# Altium schematic sheet FPGA_MISC.SchDoc(FPGA_MISC)
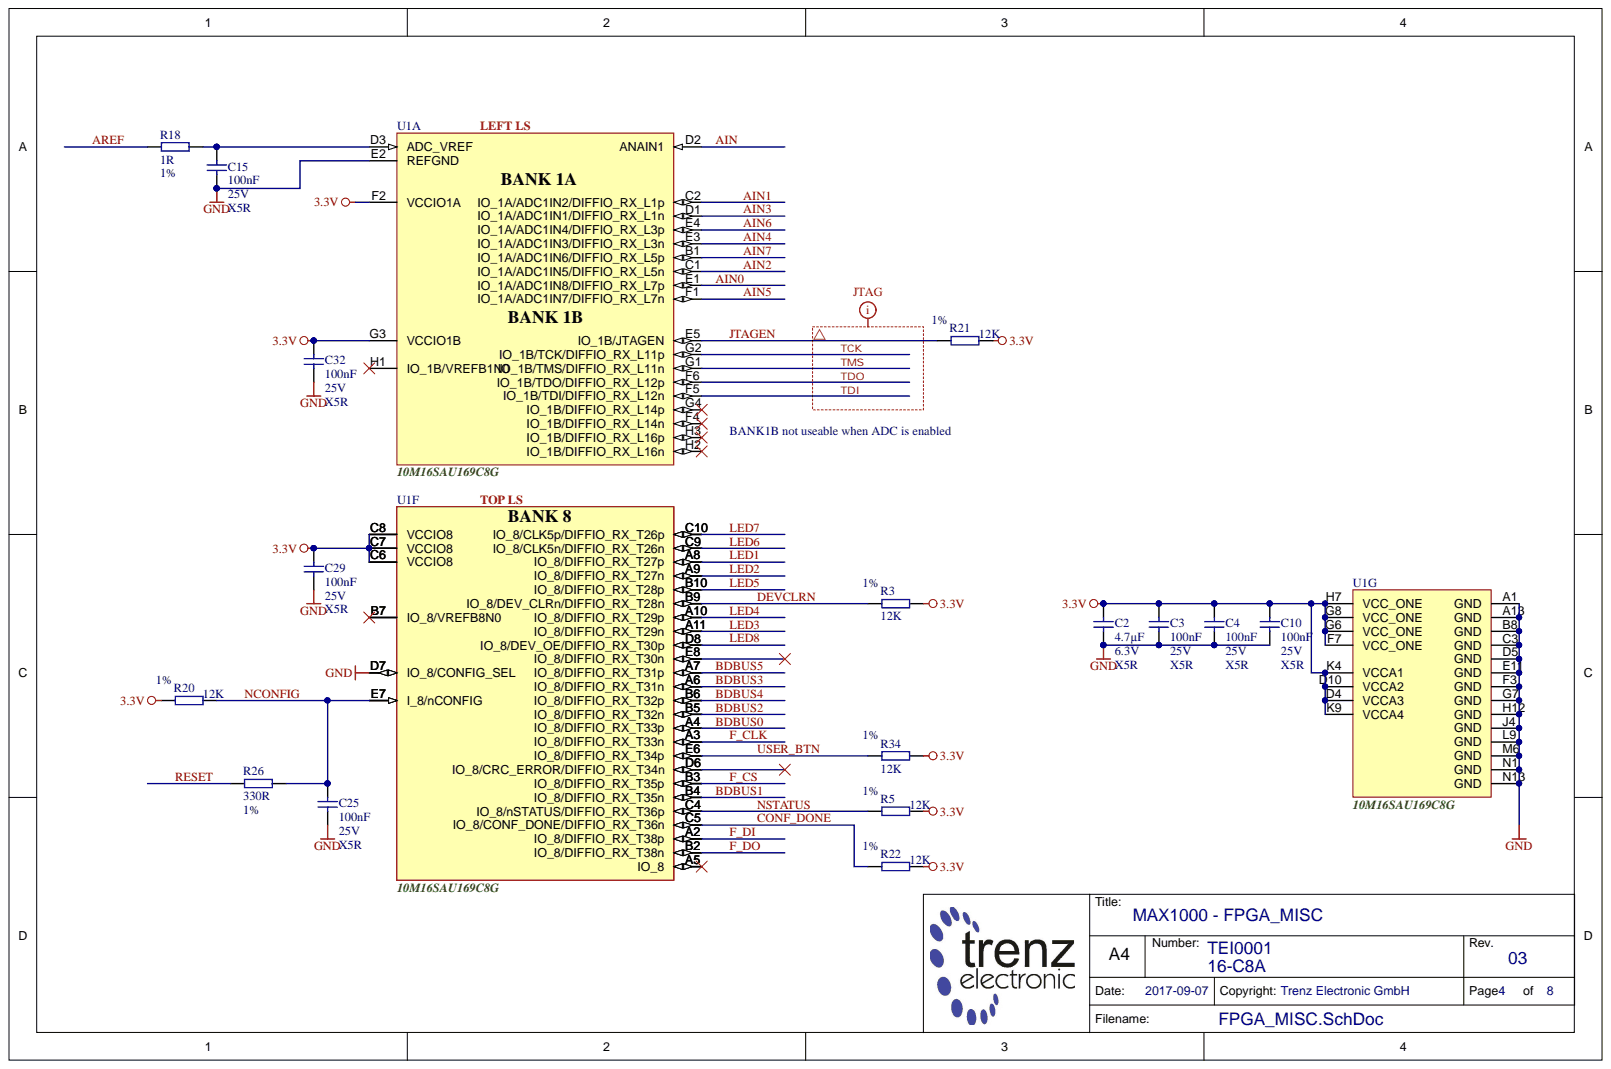
[diagram p0: FPGA_MISC.SchDoc]

## Parts
C2  4.7µF  Technology: X5R  Voltage: 6.3V  [CAPC1005X60N]
C3  100nF  Technology: X5R  Voltage: 25V  [CAPC1005X55N]
C4  100nF  Technology: X5R  Voltage: 25V  [CAPC1005X55N]
C10  100nF  Technology: X5R  Voltage: 25V  [CAPC1005X55N]
C15  100nF  Technology: X5R  Voltage: 25V  [CAPC1005X55N]
C25  100nF  Voltage: 25V  [CAPC1005X55N]
C29  100nF  Technology: X5R  Voltage: 25V  [CAPC1005X55N]
C32  100nF  Technology: X5R  Voltage: 25V  [CAPC1005X55N]
R3  12K  Tolerance: 1%  [RESC1005X40N]
R5  12K  Tolerance: 1%  [RESC1005X40N]
R18  1R  Tolerance: 1%  [RESC1005X40N]
R20  12K  Tolerance: 1%  [RESC1005X40N]
R21  12K  Tolerance: 1%  [RESC1005X40N]
R22  12K  Tolerance: 1%  [RESC1005X40N]
R26  330R  Tolerance: 1%  [RESC1005X40N]
R34  12K  Tolerance: 1%  [RESC1005X40N]
U1  10M08SAU169C8G  [BGA169C80P13X13_11X11X15]  (value not drawn)  (parts A,F,G)
U1  10M16SAU169C8G  [BGA169C80P13X13_11X11X15]  (part A)
U1F
U1F

## Nets
3.3V: C2.1 C3.1 C4.1 C10.1 C29.1 C32.1 R3.2 R5.2 R20.1 R21.2 R22.2 R34.2 U1.C6(VCCIO8) U1.C7(VCCIO8) U1.C8(VCCIO8) U1.D4 U1.D10 U1.F2 U1.F7 U1.G3 U1.G6 U1.G8 U1.H7 U1.K4 U1.K9
GND: C2.2 C3.2 C4.2 C10.2 C15.2 C25.2 C29.2 C32.2 U1.A1 U1.A13 U1.B8 U1.C3 U1.D5 U1.D7(IO_8/CONFIG_SEL) U1.E2 U1.E11 U1.F3 U1.G7 U1.H12 U1.J4 U1.L9 U1.M6 U1.N1 U1.N13
AIN: U1.D2
AIN0: U1.E1
AIN1: U1.C2
AIN2: U1.C1
AIN3: U1.D1
AIN4: U1.E3
AIN5: U1.F1
AIN6: U1.E4
AIN7: U1.B1
AREF: R18.1
BDBUS0: U1.A4(IO_8/DIFFIO_RX_T33p)
BDBUS1: U1.B4(IO_8/DIFFIO_RX_T35n)
BDBUS2: U1.B5(IO_8/DIFFIO_RX_T32n)
BDBUS3: U1.A6(IO_8/DIFFIO_RX_T31n)
BDBUS4: U1.B6(IO_8/DIFFIO_RX_T32p)
BDBUS5: U1.A7(IO_8/DIFFIO_RX_T31p)
CONF_DONE: R22.1 U1.C5(IO_8/CONF_DONE/DIFFIO_RX_T36n)
DEVCLRN: R3.1 U1.B9(IO_8/DEV_CLRn/DIFFIO_RX_T28n)
F_CLK: U1.A3(IO_8/DIFFIO_RX_T33n)
F_CS: U1.B3(IO_8/DIFFIO_RX_T35p)
F_DI: U1.A2(IO_8/DIFFIO_RX_T38p)
F_DO: U1.B2(IO_8/DIFFIO_RX_T38n)
JTAGEN: R21.1 U1.E5
LED1: U1.A8(IO_8/DIFFIO_RX_T27p)
LED2: U1.A9(IO_8/DIFFIO_RX_T27n)
LED3: U1.A11(IO_8/DIFFIO_RX_T29n)
LED4: U1.A10(IO_8/DIFFIO_RX_T29p)
LED5: U1.B10(IO_8/DIFFIO_RX_T28p)
LED6: U1.C9(IO_8/CLK5n/DIFFIO_RX_T26n)
LED7: U1.C10(IO_8/CLK5p/DIFFIO_RX_T26p)
LED8: U1.D8(IO_8/DEV_OE/DIFFIO_RX_T30p)
NCONFIG: C25.1 R20.2 R26.2 U1.E7(I_8/nCONFIG)
NSTATUS: R5.1 U1.C4(IO_8/nSTATUS/DIFFIO_RX_T36p)
RESET: R26.1
TCK: U1.G2
TDI: U1.F5
TDO: U1.F6
TMS: U1.G1
USER_BTN: R34.1 U1.E6(IO_8/DIFFIO_RX_T34p)
NetC15_1: C15.1 R18.2 U1.D3
N00189:

Not connected: U1 A5(IO_8) B7(IO_8/VREFB8N0) D6(IO_8/CRC_ERROR/DIFFIO_RX_T34n) E8(IO_8/DIFFIO_RX_T30n) F4 G4 H1 H2 H3

## Library data of the parts
C2 = 24719: KON 4.7µF 6.3V X5R SMD 20%; Database Table Name: C_1005_0402; Mixed: SMT; Preferred: Yes; Value: 4.7u; AN: 24719; Case: 1005 (0402); Component Kind: Standard; Library Name: TE.DbLib; Tolerance: 20%
C3 C4 C10 C15 C25 C29 C32 = 22472: KON 100nF 25V X5R SMD ±10%; Database Table Name: C_1005_0402; Mixed: SMT; Preferred: Yes; Value: 100n; AN: 22472; Case: 1005 (0402); Component Kind: Standard; Library Name: TE.DbLib
  C25: Technology: X5R
R3 R5 R20-R22 R34 = 22598: WID SMD 12K 1% 0,063W; Database Table Name: R_1005_0402; Mixed: SMT; Preferred: Yes; AN: 22598; Case: 1005 (0402); Component Kind: Standard; Library Name: TE.DbLib; Technology: TK100; Value: 12K
R18 = 27510: WID SMD 1R 1% 0,063W; Database Table Name: R_1005_0402; Mixed: SMT; Value: 1; AN: 27510; Case: 1005 (0402); Component Kind: Standard; Library Name: TE.DbLib; Technology: TK100
R26 = 22762: WID SMD 330R 1% 0,063W; Database Table Name: R_1005_0402; Mixed: SMT; Preferred: Yes; Value: 330; AN: 22762; Case: 1005 (0402); Component Kind: Standard; Library Name: TE.DbLib; Technology: TK100
U1 = 27930: Database Table Name: PROG_LOGIC; Mixed: SMT; AN: 27930; Case: LFBGA169; Component Kind: Standard; Description: 10M08SAU169C8G; H-ART: 10M08SAU169C8G; Library Name: TE.DbLib; HelpURL: b:\AD\adlib\datasheet\ALTERA_MAX10\
U1 = 28541: Database Table Name: PROG_LOGIC; Mixed: SMT; AN: 28541; Case: LFBGA169; Component Kind: Standard; Description: 10M16SAU169C8G; H-ART: 10M16SAU169C8G; Library Name: TE.DbLib; HelpURL: B:\AD\adlib\datasheet\ALTERA_MAX10
